# Altium schematic sheet Spanningsbronschakelaar.SchDoc(Spanningsbronschakelaar)
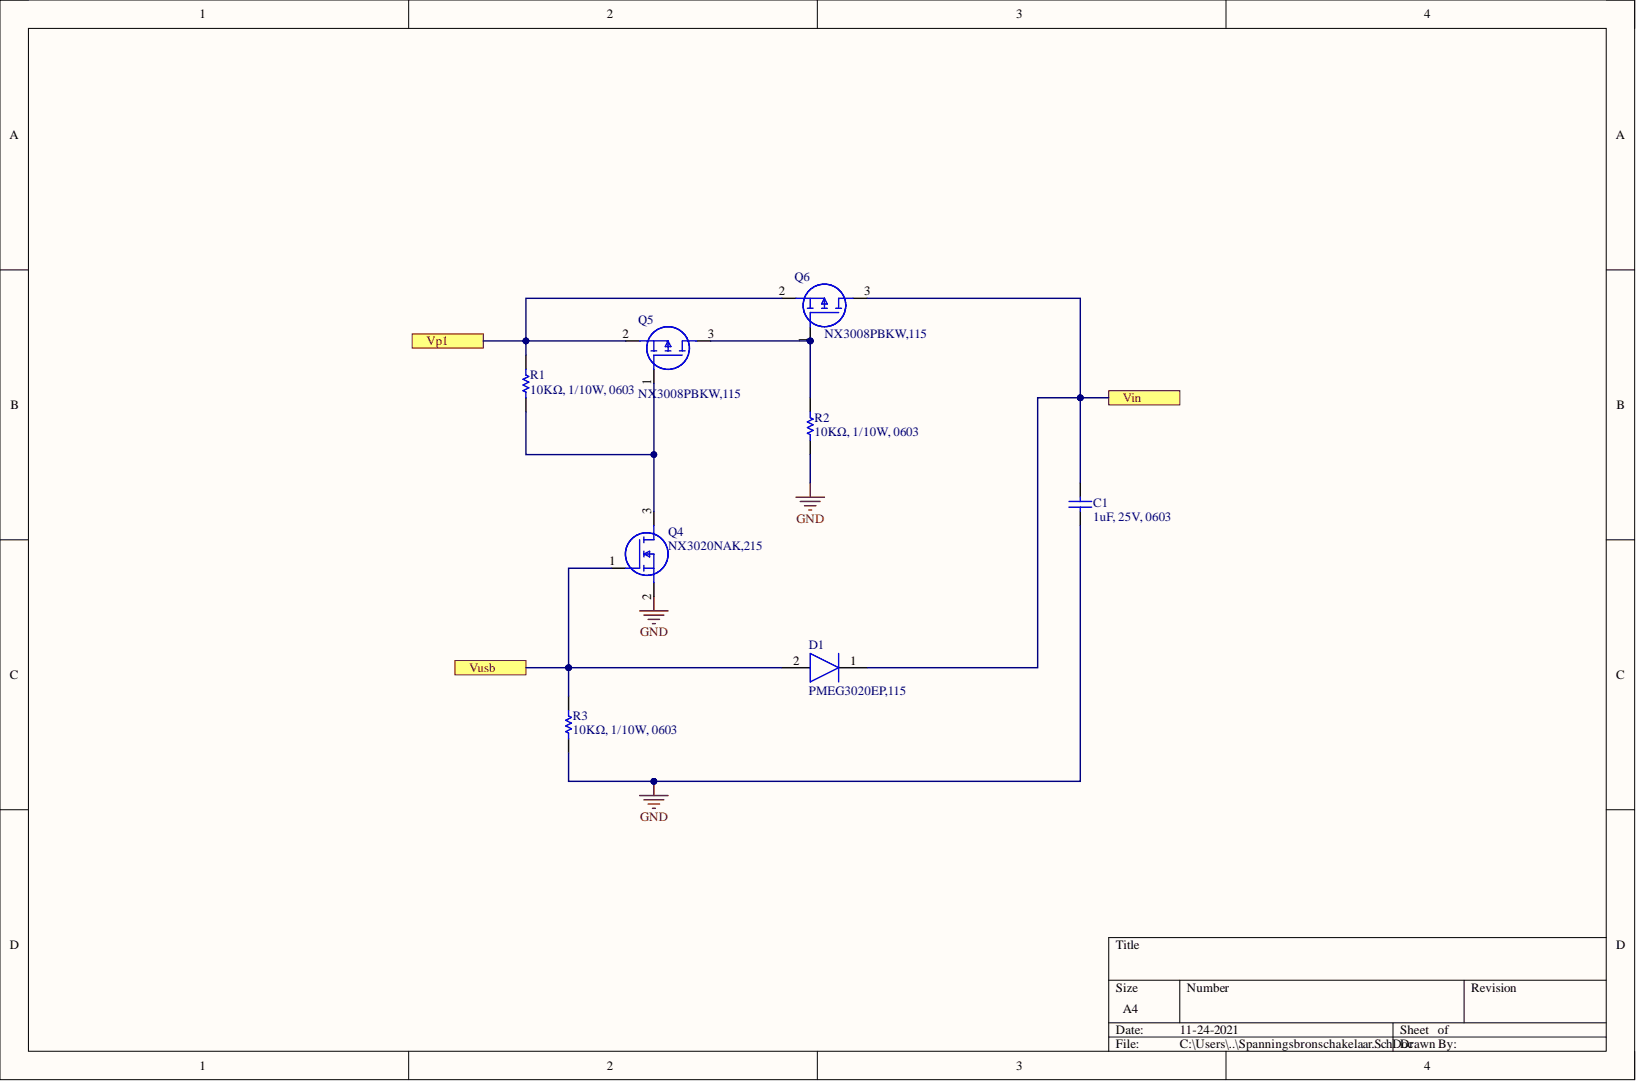
[diagram p0: Spanningsbronschakelaar.SchDoc]

## Parts
C1  1uF, 25V, 0603  [CAPC1608X90X35LL10T15]
D1  PMEG3020EP,115  [SODFL4725X110N]
Q4  NX3020NAK,215  [SOT95P230X110-3N]
Q5  NX3008PBKW,115  [SOT65P210X110-3N]
Q6  NX3008PBKW,115  [SOT65P210X110-3N]
R1  10KΩ, 1/10W, 0603  [RESC1609X50X30NL8T20]
R2  10KΩ, 1/10W, 0603  [RESC1609X50X30NL8T20]
R3  10KΩ, 1/10W, 0603  [RESC1609X50X30NL8T20]

## Ports
Vin, Vp1, Vusb

## Nets
GND: C1.1 Q4.2 R2.1 R3.1
Vin: C1.2 D1.1 Q6.3 ; port Vin
Vp1: Q5.2 Q6.2 R1.2 ; port Vp1
Vusb: D1.2 Q4.1 R3.2 ; port Vusb
NetQ4_3: Q4.3 Q5.1 R1.1
NetQ5_3: Q5.3 Q6.1 R2.2

## Library data of the parts
C1 = CMP-2006-02459-1: Manufacturer: Murata; Part Number: GRM188R61E105KA12D; Component Kind: Standard; Library Name: Altium Content Vault
D1 = PMEG3020EP,115: Diode; Manufacturer_Name: Nexperia; Component Kind: Standard; Datasheet Link: https://componentsearchengine.com//PMEG3020EP,115.pdf; Height: 1.1mm; Manufacturer_Part_Number: PMEG3020EP,115; Mouser2 Part Number: 771-PMEG3020EP115; Mouser2 Price/Stock: https://www.mouser.com/Search/Refine.aspx?Keyword=771-PMEG3020EP115
Q4 = NX3020NAK,215: MOSFET (N-Channel); Manufacturer_Name: Nexperia; Component Kind: Standard; Datasheet Link: https://assets.nexperia.com/documents/data-sheet/NX3020NAK.pdf; Height: 1.1mm; Library Name: SamacSys.SchLib; Manufacturer_Part_Number: NX3020NAK,215
Q5 Q6 = NX3008PBKW,115: MOSFET (P-Channel); Manufacturer_Name: Nexperia; Component Kind: Standard; Datasheet Link: https://www.verical.com/datasheet/nexperia-fet---mosfet-NX3008PBKW-115-5382900.pdf; Height: 1.1mm; Library Name: SamacSys.SchLib; Manufacturer_Part_Number: NX3008PBKW,115
R1-R3 = CMP-1666-00005-4: Chip Resistor, 10 KOhm, +/- 1%, 100 mW, -55 to 155 degC, 0603 (1608 Metric), RoHS, Tape and Reel; Manufacturer: Vishay Semiconductor; Voltage Rating: 75 V; Component Kind: Standard; ComponentLink1Description: Manufacturer URL; ComponentLink1URL: http://www.vishay.com/; ComponentLink2Description: Datasheet; ComponentLink2URL: https://datasheet.ciiva.com/11809/0900766b80d98afc-11809037.pdf; DatasheetVersion: 06/2012; Height: 0.45 mm; Length: 1.55 mm; Library Name: Altium Content Vault; Max Operating Temperature: 155 degC; Min Operating Temperature: -55 degC; Mounting Technology: Surface Mount; Package Quantity: 5000; PackageDescription: 2-Pin Surface Mount Device, Body 1.575 x 0.85 mm; PackageReference: 0603; Packaging: Tape and Reel; REACH SVHC: Unknown; RoHSCompliant: true
